# Altium schematic sheet Sensor_Box_PWR_DC.SchDoc
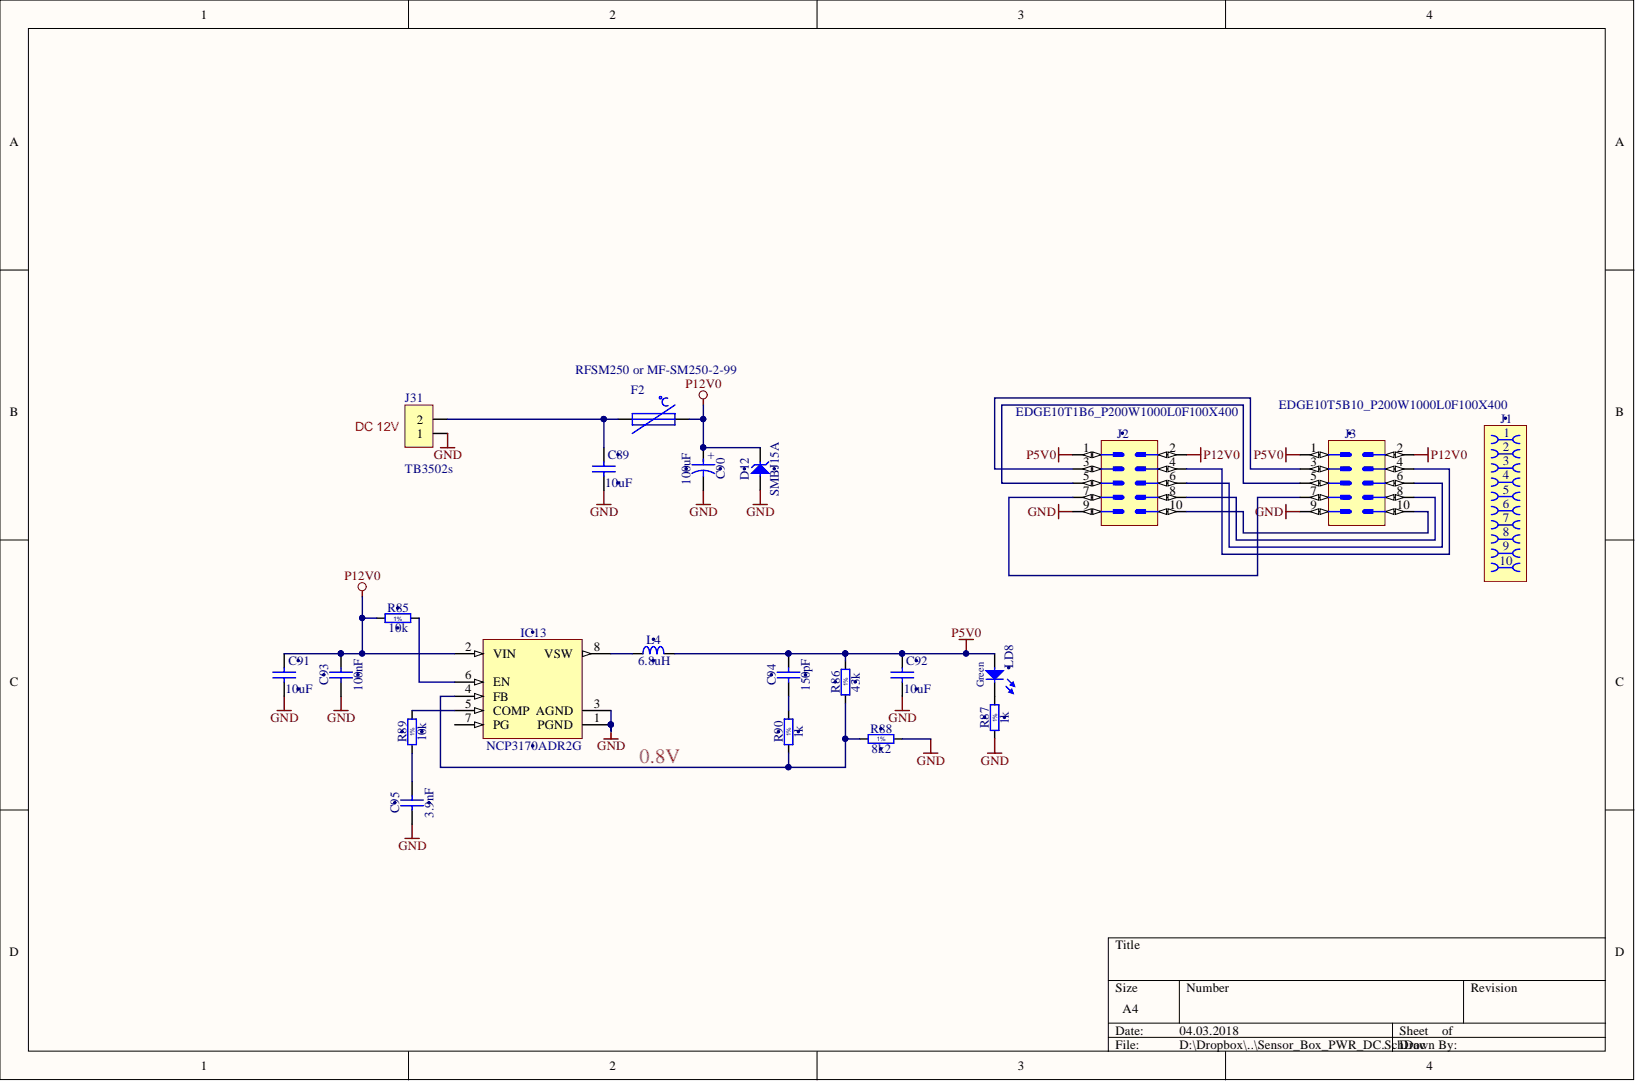
[diagram p0: Sensor_Box_PWR_DC.SchDoc]

## Parts
C89  10uF  [CAPC2012X135N]
C90  100uF  [CAPAE660X540N]
C91  10uF  [CAPC2012X135N]
C92  10uF  [CAPC2012X135N]
C93  100nF  [CAPC1005X55N]
C94  150pF  [CAPC1608X87N]
C95  3.9nF  [CAPC1608X87N]
D12  SMBJ15A  [DIOM5336X265N]
F2  RFSM250 or MF-SM250-2-99  [SMD150-2]
IC13  NCP3170ADR2G  [SOIC127P600X175-8N]
J1  Family  [AVX_00 9159 010 061 916]  (value not drawn)
J2  Family  [EDGE10T1B6_P200W1000L0F100X400]  (value not drawn)  (no BOM)
J3  Family  [EDGE10T5B10_P200W1000L0F100X400]  (value not drawn)  (no BOM)
J31  TB3502s  [tbx3502s]
L4  6.8uH  [IND_BOURNS_SRU8043]
LD8  Green  [LED_KINGBRIGHT_KPTR3216]
R85  10k  [RESC1005X40N]
R86  43k  [RESC1608X55N]
R87  1k  [RESC1005X40N]
R88  8k2  [RESC1608X55N]
R89  10k  [RESC1005X40N]
R90  1k  [RESC1005X40N]

## Nets
GND: C89.2 C90.2 C91.2 C92.2 C93.1 C95.1 D12.2 IC13.1(PGND) IC13.3(AGND) J2.9 J3.9 J31.1 R87.1 R88.2
P5V0: C92.1 C94.2 J2.1 J3.1 L4.2 LD8.2 R86.2
P12V0: C90.1 C91.1 C93.2 D12.1 F2.2 IC13.2(VIN) J2.2 J3.2 R85.1
NetC89_1: C89.1 F2.1 J31.2
NetC94_1: C94.1 R90.2
NetC95_2: C95.2 R89.1
NetIC13_4: IC13.4(FB) R86.1 R88.1 R90.1
NetIC13_5: IC13.5(COMP) R89.2
NetIC13_6: IC13.6(EN) R85.2
NetIC13_8: IC13.8(VSW) L4.1
NetJ2_3: J2.3 J3.3
NetJ2_4: J2.4 J3.4
NetJ2_5: J2.5 J3.5
NetJ2_6: J2.6 J3.6
NetJ2_7: J2.7 J3.7
NetJ2_8: J2.8 J3.8
NetJ2_10: J2.10 J3.10
NetLD8_1: LD8.1 R87.2

Not connected: IC13 7(PG)

## Library data of the parts
C89 C91 C92 = CC0805_10UF_10V_10%_X7R: Non-polarised capacitor; Manufacturer: GENERIC; Manufacturer1 Part Number: GRM21BR71A106KE51L; Part Description: SMD Multilayer Chip Ceramic Capacitor; Database Table Name: Capacitors SMD; TC: X7R; Tolerance: ±10%; Voltage: 10V; Case: 0805; Component Kind: Standard; Component Type: Standard; CreateDate: 2012-04-30; HelpURL: \\cern.ch\dfs\Applications\Altium\Datasheets\CC0805_X7R_MURATA.pdf; LatestRevisionDate: 2012-04-30; Library Name: Capacitors.DbLib; Manufacturer1 ComponentHeight: 1.35mm; Manufacturer1 Example: MURATA; Manufacturer Part Number: CC0805_10UF_10V_10%_X7R; Mounted: Yes; Part Number: CC0805_10UF_10V_10%_X7R; Pin Count: 2; SMD: Yes; Socket: No; Status: Preferred; Table: Capacitors SMD; Value: 10uF; Author: CERN DEM JLC
C90 = CAE660-540_100UF_16V_20%: Polarised capacitor; Manufacturer: PANASONIC; Manufacturer Part Number: EEEFK1C101AP; Part Description: Aluminum Electrolytic SMT Capacitor; Database Table Name: Capacitors SMD; Tolerance: ±20%; Voltage: 16V; Case: D; ComponentHeight: 5.8mm; Component Kind: Standard; Component Type: Standard; CreateDate: 03.12.2007; HelpURL: \\cern.ch\dfs\Applications\Altium\Datasheets\CAE_PANASONIC_FK.pdf; LatestRevisionDate: 05.11.2014; Library Name: Capacitors.DbLib; Mounted: Yes; Part Number: CAE660-540_100UF_16V_20%; Pin Count: 2; Sense: No; SMD: Yes; Socket: No; Status: Not Recommended; Value: 100uF; Author: CERN DEM JLC
C93 = CC0402_100NF_16V_10%_X5R: Non-polarised capacitor; Manufacturer: GENERIC; Manufacturer1 Part Number: GRM155R61C104KA88D; Part Description: SMD Multilayer Chip Ceramic Capacitor; Database Table Name: Capacitors SMD; TC: X5R; Tolerance: ±10%; Voltage: 16V; Case: 0402; Component Kind: Standard; Component Type: Standard; CreateDate: 10.12.2013; HelpURL: \\cern.ch\dfs\Applications\Altium\Datasheets\CC0402_X5R_MURATA_GRM.pdf; LatestRevisionDate: 10.12.2013; Library Name: Capacitors.DbLib; Manufacturer1 ComponentHeight: 0.55mm; Manufacturer1 Example: MURATA; Manufacturer Part Number: CC0402_100NF_16V_10%_X5R; Mounted: Yes; Part Number: CC0402_100NF_16V_10%_X5R; Pin Count: 2; Sense: No; SMD: Yes; Socket: No; Status: None; Value: 100nF; Author: CERN DEM JLC
C94 = CC0603_150PF_50V_1%_NP0: Non-polarised capacitor; Manufacturer: GENERIC; Manufacturer1 Part Number: CC0603FRNPO9BN151; Part Description: SMD Multilayer Chip Ceramic Capacitor; Database Table Name: Capacitors SMD; TC: C0G/NP0; Tolerance: ±1%; Voltage: 50V; Case: 0603; Component Kind: Standard; Component Type: Standard; CreateDate: 17.02.2016; HelpURL: \\cern.ch\dfs\Applications\Altium\Datasheets\CC0805_NP0_PHYCOMP_16VTO50V.pdf; LatestRevisionDate: 17.02.2016; Library Name: Capacitors.DbLib; Manufacturer1 ComponentHeight: 0.7mm; Manufacturer1 Example: PHYCOMP; Manufacturer Part Number: CC0603_150PF_50V_1%_NP0; Mounted: Yes; Part Number: CC0603_150PF_50V_1%_NP0; Pin Count: 2; Sense: No; SMD: Yes; Socket: No; Status: None; Value: 150pF; Author: CERN DEM MR
C95 = CC0603_3.9NF_50V_5%_X7R: Non-polarised capacitor; Manufacturer: GENERIC; Manufacturer1 Part Number: 223858615631; Part Description: SMD Multilayer Chip Ceramic Capacitor; Database Table Name: Capacitors SMD; TC: X7R; Tolerance: ±5%; Voltage: 50V; Case: 0603; Component Kind: Standard; Component Type: Standard; CreateDate: 03.12.2007; HelpURL: \\cern.ch\dfs\Applications\Altium\Datasheets\CC0603_X7R_PHYCOMP.pdf; LatestRevisionDate: 03.12.2007; Library Name: Capacitors.DbLib; Manufacturer1 ComponentHeight: 0.87mm; Manufacturer1 Example: PHYCOMP; Manufacturer Part Number: CC0603_3.9NF_50V_5%_X7R; Mounted: Yes; Part Number: CC0603_3.9NF_50V_5%_X7R; Pin Count: 2; Sense: No; SMD: Yes; Socket: No; Status: Preferred; Value: 3.9nF; Author: CERN DEM JLC
D12 = SMBJ15A: Zener Diode; Manufacturer: FAIRCHILD SEMICONDUCTOR; Part Description: Uni-Directional Transient Voltage Suppressor Diode; Bonding: No; Database Table Name: Diodes; Power: 600W; PressFit: No; Voltage: 15V; Case: DO-214AA; ComponentHeight: 2.65mm; Component Kind: Standard; Component Type: Standard; CreateDate: 08.12.2008; Device: SMBJ15A; HelpURL: \\cern.ch\dfs\Applications\Altium\Datasheets\SMBJ.pdf; LatestRevisionDate: 19.12.2011; Library Name: ICs And Semiconductors.DbLib; Manufacturer Part Number: SMBJ15A; Mounted: Yes; PackageDescription: DO-214-AA/SMB Diode, Molded Body; 5.34mm L X 3.625mm W X 2.65mm H, IPC Medium Density; Part Number: SMBJ15A; Pin Count: 2; Sense: No; SMD: Yes; Socket: No; Author: CERN DEM JLC
F2 = F_PTC_9.5x6mm: 2.50A/15V; Component Kind: Standard; Library Name: LIBRARY_SCH.SCHLIB; Supplier 1: Newark; Supplier Part Number 1: 61J7435
IC13 = NCP3170ADR2G: Synchronous PWM Switching Converter; Manufacturer: ON SEMICONDUCTOR; Bonding: No; Database Table Name: Analog & Interface; PressFit: No; Case: SOIC8; ComponentHeight: 1.75mm; Component Kind: Standard; Component Type: Standard; CreateDate: 30.05.2016; Device: NCP3170ADR2G; HelpURL: \\cern.ch\dfs\Applications\Altium\Datasheets\NCP3170ADR2G.pdf; LatestRevisionDate: 30.05.2016; Library Name: ICs And Semiconductors.DbLib; Manufacturer Part Number: NCP3170ADR2G; Mounted: Yes; PackageDescription: SOIC 8, Pitch 1.27mm - Body 4x5mm, IPC Medium Density; Part Description: Synchronous PWM Switching Converter; Part Number: NCP3170ADR2G; Pin Count: 8; Sense: No; SMD: Yes; Socket: No; Author: CERN DEM MR
J1 = AVX_00 9159 010 061 916: Open Ended Card Edge Connector, 9159 Series; Manufacturer: AVX; Part Description: 10 Contacts, 2mm Pitch, Open Ended Card Edge Connector, 9159 Series; Database Table Name: Miscellaneous; PressFit: No; ComponentHeight: 1.6mm; Component Kind: Standard; Component Type: Standard; CreateDate: 13.02.2018; HelpURL: \\cern.ch\dfs\Applications\Altium\Datasheets\AVX_00 9159 010 061 916.pdf; LatestRevisionDate: 13.02.2018; Library Name: Connectors.DbLib; Manufacturer Part Number: 00 9159 010 061 916; Mounted: Yes; Part Number: AVX_00 9159 010 061 916; Pin Count: 10; Sense: No; SMD: No; Socket: No; Status: None; Author: CERN DEM MR
J2 = EDGE10T1B6_P200W1000L0F100X400: PCB Edge Connector 10 (2x5); Part Description: 10 Contacts Board Edge Connector, Pitch 2mm, Length 0mm, Width 10mm, Finger Lenght 4mm, Finger Width 1mm; Database Table Name: Miscellaneous; Mounted: No; PressFit: No; ComponentHeight: 0mm; Component Type: Standard (No BOM); CreateDate: 14.02.2018; HelpURL: \\cern.ch\dfs\Applications\Altium\Datasheets\EDGE10T1B6_P200W1000L0F100X400.pdf; LatestRevisionDate: 14.02.2018; Library Name: Connectors.DbLib; Part Number: EDGE10T1B6_P200W1000L0F100X400; Pin Count: 10; Sense: No; SMD: No; Socket: No; Status: None; Author: CERN DEM MR
J3 = EDGE10T5B10_P200W1000L0F100X400: PCB Edge Connector 10 (2x5); Part Description: 10 Contacts Board Edge Connector, Pitch 2mm, Length 0mm, Width 10mm, Finger Lenght 4mm, Finger Width 1mm; Database Table Name: Miscellaneous; Mounted: No; PressFit: No; ComponentHeight: 0mm; Component Type: Standard (No BOM); CreateDate: 14.02.2018; HelpURL: \\cern.ch\dfs\Applications\Altium\Datasheets\EDGE10T5B10_P200W1000L0F100X400.pdf; LatestRevisionDate: 14.02.2018; Library Name: Connectors.DbLib; Part Number: EDGE10T5B10_P200W1000L0F100X400; Pin Count: 10; Sense: No; SMD: No; Socket: No; Status: None; Author: CERN DEM MR
J31 = CON_TB2: Terminal Block; Component Kind: Standard; Library Name: LIBRARY_SCH.SCHLIB
L4 = IND_6.8UH_30%_BOURNS_SRU8043: Inductor; Manufacturer: BOURNS; Manufacturer Part Number: SRU8043-6R8Y; Part Description: Shielded SMD Power Inductor; Database Table Name: Inductors SMD; Power: 3.8A; Resistance: 0.0224R; Tolerance: ±30%; ComponentHeight: 4.6mm; Component Kind: Standard; Component Type: Standard; CreateDate: 06.08.2015; HelpURL: \\cern.ch\dfs\Applications\Altium\Datasheets\IND_BOURNS_SRU8043.pdf; LatestRevisionDate: 06.08.2015; Library Name: Inductors & Transformers.DbLib; Mounted: Yes; Part Number: IND_6.8UH_30%_BOURNS_SRU8043; Pin Count: 2; Sense: No; SMD: Yes; Socket: No; Status: None; Value: 6.8uH; Author: CERN DEM JMW
LD8 = LED_KINGBRIGHT_KPTR-3216CGCK: LED Green 1A 2C; Part Description: Reverse Mount LED 1.4x1.6mm Green; Bonding: No; Database Table Name: LEDs & Displays; PressFit: No; Color: Green; ComponentHeight: 0.35mm; Component Kind: Standard; Component Type: Standard; CreateDate: 15.02.2016; HelpURL: \\cern.ch\dfs\Applications\Altium\Datasheets\LED_KINGBRIGHT_KPTR-3216CGCK.pdf; LatestRevisionDate: 15.02.2016; Library Name: ICs And Semiconductors.DbLib; Manufacturer: KINGBRIGHT; Manufacturer Part Number: KPTR-3216CGCK; Mounted: Yes; PackageDescription: Reverse Mount LED 3216, Hole 2.1x2.3mm 0.35mm Height; Part Number: LED_KINGBRIGHT_KPTR-3216CGCK; Pin Count: 2; Sense: No; SMD: Yes; Socket: No; Author: CERN DEM MR
R85 R89 = R0402_10K_1%_0.0625W_100PPM: Resistor - 1%; Manufacturer: GENERIC; Manufacturer1 Part Number: 232270671003L; Part Description: General Purpose Thick Film Chip Resistor; Database Table Name: Resistors SMD; PressFit: No; TC: ±100ppm/°C; Case: 0402; Component Kind: Standard; Component Type: Standard; CreateDate: 03.12.2007; HelpURL: \\cern.ch\dfs\Applications\Altium\Datasheets\R0402_Phycomp_RC0402.pdf; LatestRevisionDate: 17.10.2012; Library Name: Resistors.DbLib; Manufacturer1 ComponentHeight: 0.4mm; Manufacturer1 Example: YAGEO PHYCOMP; Manufacturer Part Number: R0402_10K_1%_0.0625W_100PPM; Mounted: Yes; Part Number: R0402_10K_1%_0.0625W_100PPM; Pin Count: 2; Power: 0.0625W; Sense: No; SMD: Yes; Socket: No; Status: Not Recommended; Tolerance: ±1%; Value: 10k; Author: CERN DEM JLC
R86 = R0603_43K_1%_0.1W_100PPM: Resistor - 1%; Manufacturer: GENERIC; Manufacturer1 Part Number: NRC06F4302TRF; Part Description: General Purpose Thick Film Chip Resistor; Database Table Name: Resistors SMD; Power: 0.1W; PressFit: No; TC: ±100ppm/°C; Case: 0603; Component Kind: Standard; Component Type: Standard; CreateDate: 03.12.2007; HelpURL: \\cern.ch\dfs\Applications\Altium\Datasheets\R0603_NIC_NRC.pdf; LatestRevisionDate: 13.03.2008; Library Name: Resistors.DbLib; Manufacturer1 ComponentHeight: 0.55mm; Manufacturer1 Example: NIC COMPONENT; Manufacturer Part Number: R0603_43K_1%_0.1W_100PPM; Mounted: Yes; Part Number: R0603_43K_1%_0.1W_100PPM; Pin Count: 2; Sense: No; SMD: Yes; Socket: No; Status: Preferred; Tolerance: ±1%; Value: 43k; Author: CERN DEM JLC
R87 R90 = R0402_1K_1%_0.0625W_100PPM: Resistor - 1%; Manufacturer: GENERIC; Manufacturer1 Part Number: 232270671002L; Part Description: General Purpose Thick Film Chip Resistor; Database Table Name: Resistors SMD; PressFit: No; TC: ±100ppm/°C; Case: 0402; Component Kind: Standard; Component Type: Standard; CreateDate: 03.12.2007; HelpURL: \\cern.ch\dfs\Applications\Altium\Datasheets\R0402_Phycomp_RC0402.pdf; LatestRevisionDate: 17.10.2012; Library Name: Resistors.DbLib; Manufacturer1 ComponentHeight: 0.4mm; Manufacturer1 Example: YAGEO PHYCOMP; Manufacturer Part Number: R0402_1K_1%_0.0625W_100PPM; Mounted: Yes; Part Number: R0402_1K_1%_0.0625W_100PPM; Pin Count: 2; Power: 0.0625W; Sense: No; SMD: Yes; Socket: No; Status: Not Recommended; Tolerance: ±1%; Value: 1k; Author: CERN DEM JLC
R88 = R0603_8K2_1%_0.1W_100PPM: Resistor - 1%; Manufacturer: GENERIC; Manufacturer1 Part Number: NRC06F8201TRF; Part Description: General Purpose Thick Film Chip Resistor; Database Table Name: Resistors SMD; Power: 0.1W; PressFit: No; TC: ±100ppm/°C; Case: 0603; Component Kind: Standard; Component Type: Standard; CreateDate: 03.12.2007; HelpURL: \\cern.ch\dfs\Applications\Altium\Datasheets\R0603_NIC_NRC.pdf; LatestRevisionDate: 13.03.2008; Library Name: Resistors.DbLib; Manufacturer1 ComponentHeight: 0.55mm; Manufacturer1 Example: NIC COMPONENT; Manufacturer Part Number: R0603_8K2_1%_0.1W_100PPM; Mounted: Yes; Part Number: R0603_8K2_1%_0.1W_100PPM; Pin Count: 2; Sense: No; SMD: Yes; Socket: No; Status: Preferred; Tolerance: ±1%; Value: 8k2; Author: CERN DEM JLC
